# Altium schematic sheet 12_CONFIGURATIN_AND_ADC.SchDoc(12_CONFIGURATIN_AND_ADC)
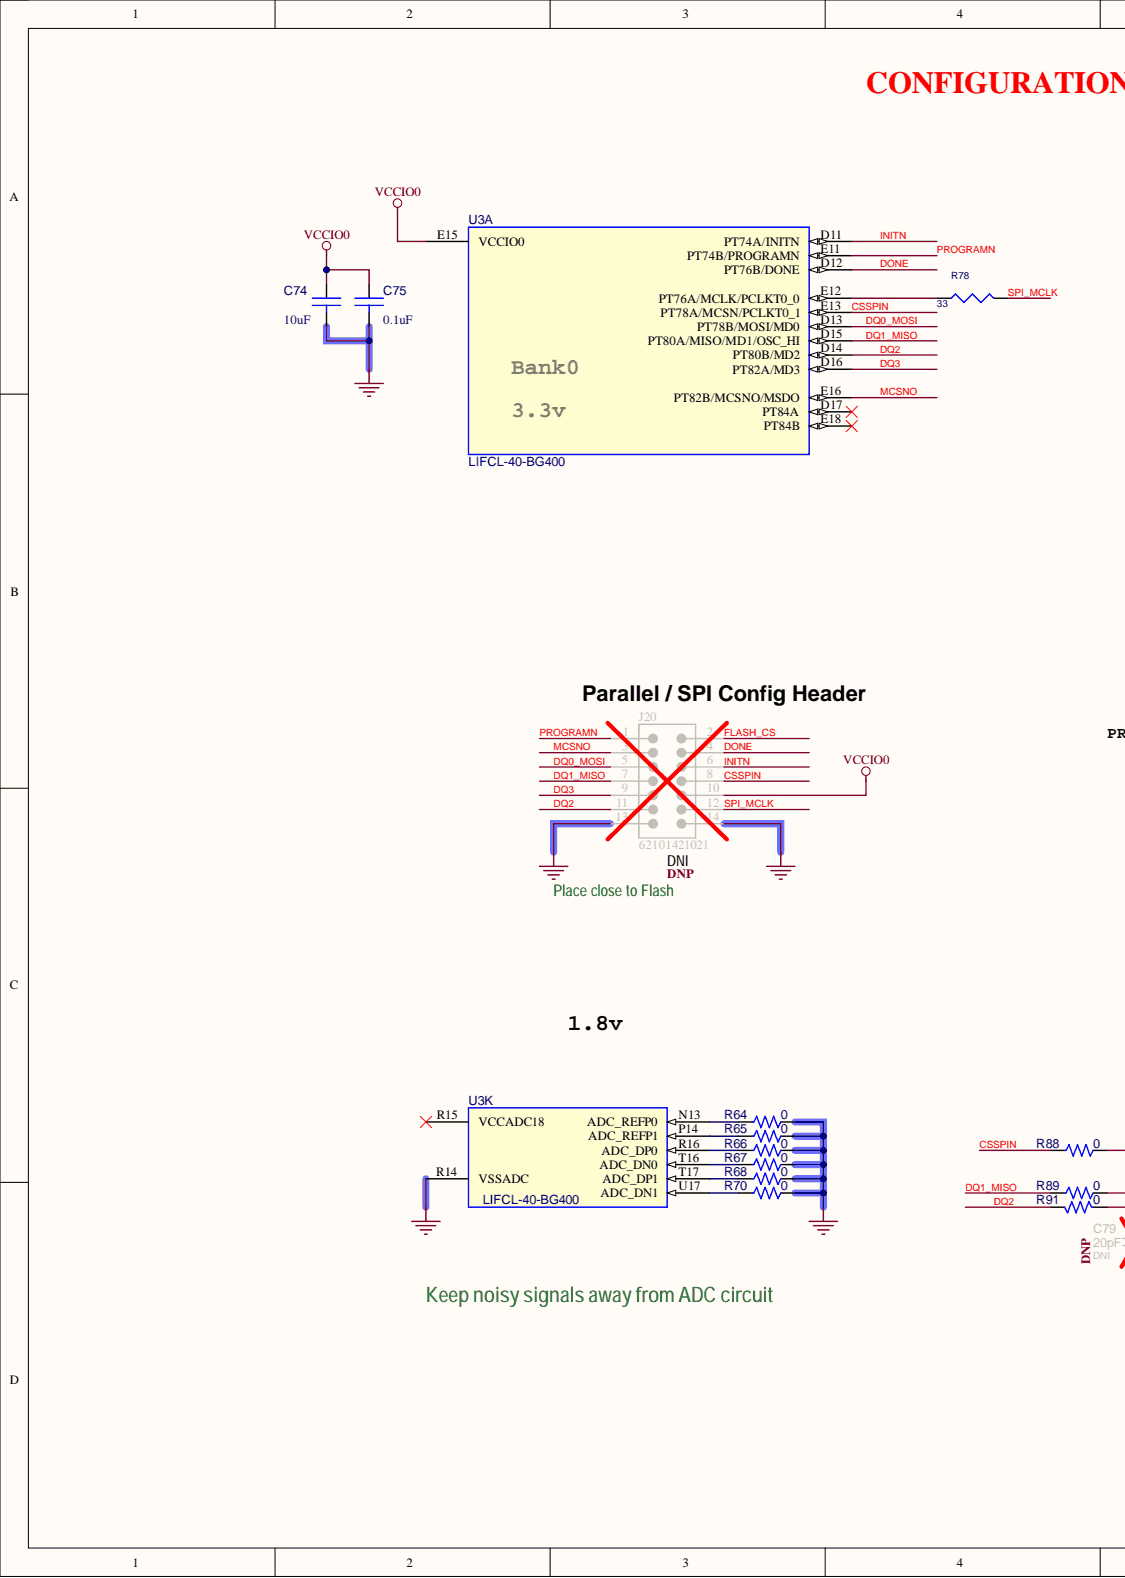
[diagram p0: 12_CONFIGURATIN_AND_ADC.SchDoc, left]
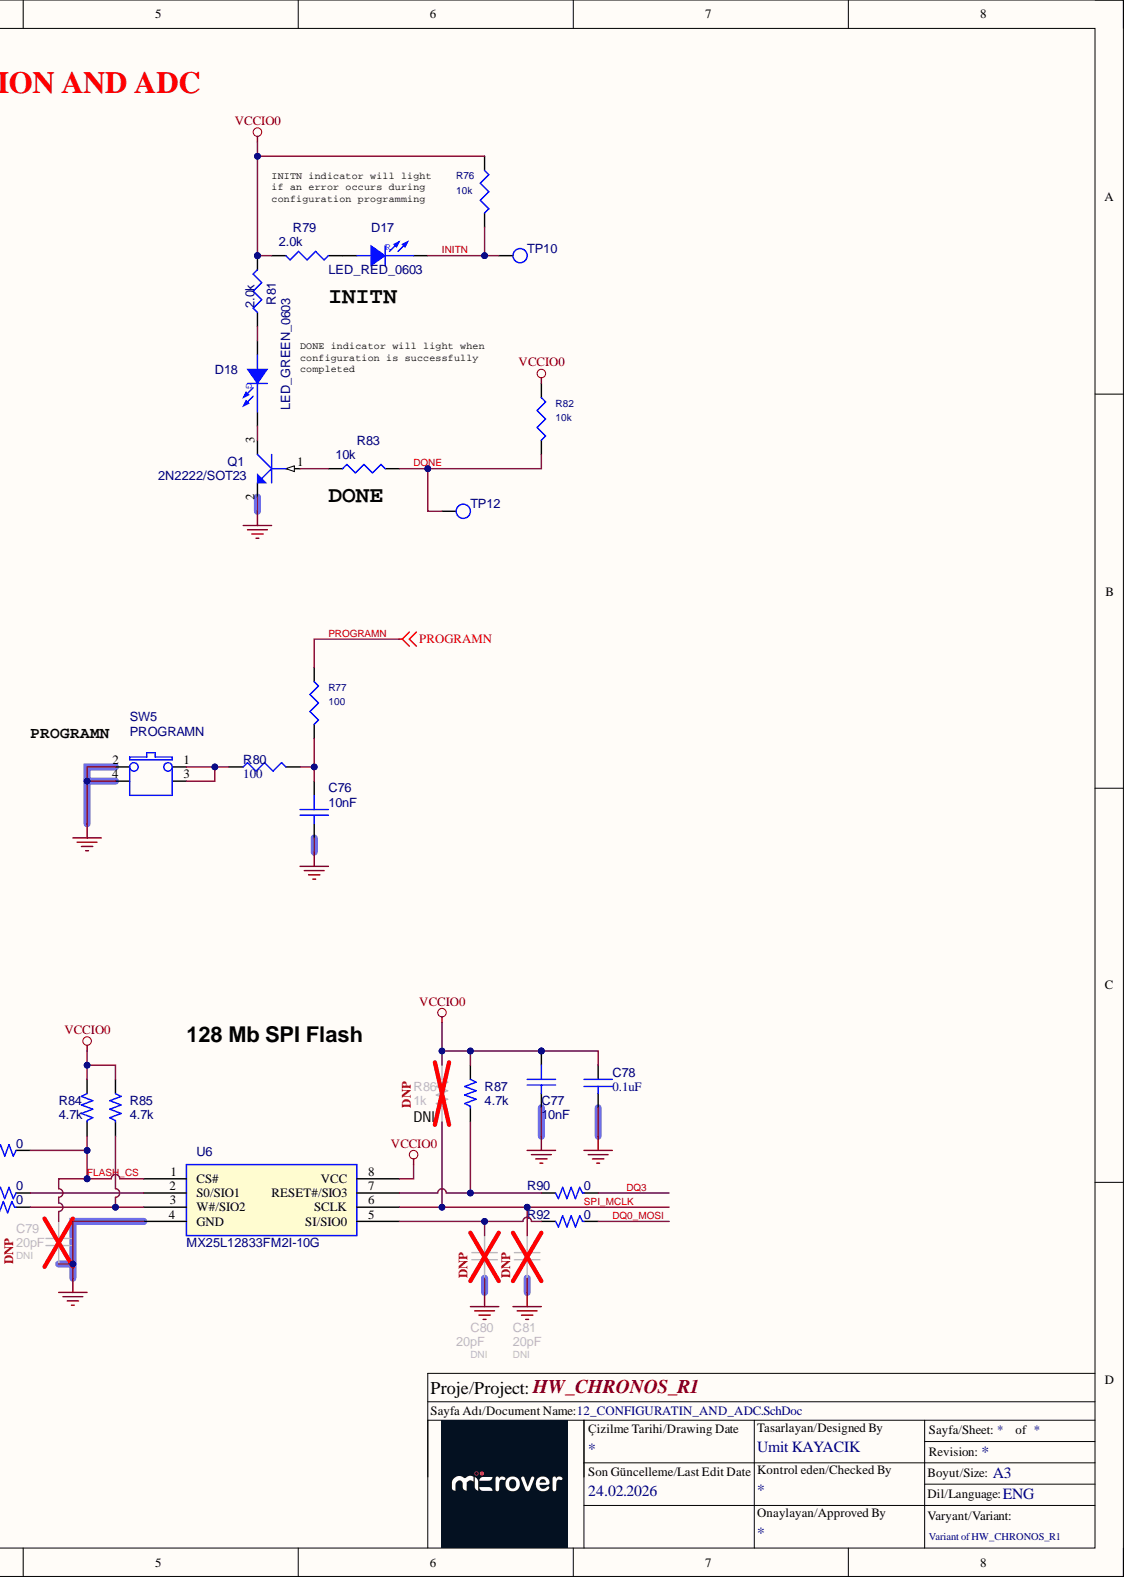
[diagram p1: 12_CONFIGURATIN_AND_ADC.SchDoc, right]

## Parts
C74  10uF  Part Reference: C74  [C0603]
C75  0.1uF  Part Reference: C75  [C0201]
C76  10nF  Part Reference: C76  [RLP-130-A]
C77  10nF  Part Reference: C77  [RLP-130-A]
C78  0.1uF  Part Reference: C78  [C0402]
C79  20pF  Assy: DNI  Part Reference: C79  populate: DNI  [C0402]
C80  20pF  [C0402]
C81  20pF  [c0402]
D17  LED_RED_0603  Part Reference: D17  [APT1608]
D18  LED_GREEN_0603  Part Reference: D18  [APT1608]
J20  62101421021  [62101421021]
Q1  2N2222/SOT23  Part Reference: Q1  [MMBT2222ALT-1]
R64  0  [R0201]
R65  0  [R0201]
R66  0  [R0201]
R67  0  [R0201]
R68  0  [R0201]
R70  0  [R0201]
R76  10k  Part Reference: R76  [R0402]
R77  100  Part Reference: R77  [R0402]
R78  33  Part Reference: R78  [R0402]
R79  2.0k  Part Reference: R79  [R0402]
R80  100  Part Reference: R80  [R0402]
R81  2.0k  Part Reference: R81  [R0402]
R82  10k  Part Reference: R82  [R0402]
R83  10k  Part Reference: R83  [R0402]
R84  4.7k  Part Reference: R84  [R0402]
R85  4.7k  Part Reference: R85  [R0402]
R86  1k  Assy: DNI  Part Reference: R86  [R0402]
R87  4.7k  Part Reference: R87  [R0402]
R88  0  Part Reference: R88  [R0402]
R89  0  Part Reference: R89  [R0402]
R90  0  Part Reference: R90  [R0402]
R91  0  Part Reference: R91  [R0402]
R92  0  Part Reference: R92  [R0402]
SW5  PROGRAMN  Part Reference: SW5  [4psmd_switch]
TP10  Part Reference: TP10  [TP_1MM]  (hidden comment: TestPoint)
TP12  Part Reference: TP12  [TP_1MM]  (hidden comment: TestPoint)
U3  LIFCL-40-BG400  [LIFCL-40-BG400]  (parts A,K)
U6  MX25L12833FM2I-10G  Part Reference: U6  [SO8_MX25L12833FM2I10G]

## Nets
GND: C74.2 C75.2 C76.2 C77.2 C78.2 C79.2 C80.2 C81.2 J20.13 J20.14 Q1.2 R64.2 R65.2 R66.2 R67.2 R68.2 R70.2 SW5.2 SW5.4 U3.R14(VSSADC) U6.4(GND)
VCCIO0: C74.1 C75.1 C77.1 C78.1 J20.10 R76.1 R79.2 R81.2 R82.1 R84.2 R85.2 R86.2 R87.2 U3.E15(VCCIO0) U6.8(VCC)
CSSPIN: J20.8 R88.1 U3.E13(PT78A/MCSN/PCLKT0_1)
DONE: J20.4 R82.2 R83.2 TP12.1 U3.D12(PT76B/DONE)
DQ0_MOSI: J20.5 R92.1 U3.D13(PT78B/MOSI/MD0)
DQ1_MISO: J20.7 R89.1 U3.D15(PT80A/MISO/MD1/OSC_HI)
DQ2: J20.11 R91.2 U3.D14(PT80B/MD2)
DQ3: J20.9 R90.1 U3.D16(PT82A/MD3)
FLASH_CS: C79.1 J20.2 R84.1 R88.2 U6.1(CS#)
INITN: D17.1 J20.6 R76.2 TP10.1 U3.D11(PT74A/INITN)
MCSNO: J20.3 U3.E16(PT82B/MCSNO/MSDO)
PROGRAMN: J20.1 R77.1 U3.E11(PT74B/PROGRAMN)
SPI_MCLK: C81.1 J20.12 R78.1 R86.1 U6.6(SCLK)
NetC76_1: C76.1 R77.2 R80.2
NetC80_1: C80.1 R92.2 U6.5(SI/SIO0)
NetD17_2: D17.2 R79.1
NetD18_1: D18.1 Q1.3
NetD18_2: D18.2 R81.1
NetQ1_1: Q1.1 R83.1
NetR64_1: R64.1 U3.N13(ADC_REFP0)
NetR65_1: R65.1 U3.P14(ADC_REFP1)
NetR66_1: R66.1 U3.R16(ADC_DP0)
NetR67_1: R67.1 U3.T16(ADC_DN0)
NetR68_1: R68.1 U3.T17(ADC_DP1)
NetR70_1: R70.1 U3.U17(ADC_DN1)
NetR78_2: R78.2 U3.E12(PT76A/MCLK/PCLKT0_0)
NetR80_1: R80.1 SW5.1 SW5.3
NetR85_1: R85.1 R91.1 U6.3(W#/SIO2)
NetR87_1: R87.1 R90.2 U6.7(RESET#/SIO3)
NetR89_2: R89.2 U6.2(S0/SIO1)

Not connected: U3 D17(PT84A) E18(PT84B) R15(VCCADC18)

## Library data of the parts
C74 = 10uF_0603: CAP CECAP CER 10UF 10V X5R 20% 0603; Manufacturer: Taiyo Yuden; Manufacturer Part Number: LMK107BJ106MALTD; Class: Discrete; Assy: -; Component Kind: Standard; Digikey P/N: 587-2562-1-ND; PCB Footprint: C0603; Value: 10uF
C75 = 0u1_0402: CAP CER 0.1UF 25V 10% X5R 0201; Manufacturer: Murata; Manufacturer Part Number: GRM033R61E104KE14J; Class: Discrete; Assy: -; Component Kind: Standard; Digikey P/N: 399-3521-2-ND; PCB Footprint: C0201; Value: 0.1uF
C76 C77 = CAP_1: Assy: -; Component Kind: Standard; PCB Footprint: RLP-130-A; Value: 10nF
C78 = 0u1_0402: CAP CER 0.1UF 25V 10% X5R 0402; Manufacturer: Murata; Manufacturer Part Number: GRM033R61E104KE14J; Class: Discrete; PCB Footprint: C0201; Assy: -; Component Kind: Standard; Digikey P/N: 399-3521-2-ND; Value: 0.1uF
C79 = CAP NP_Dup1: COMPFIXED: NO; COMPGROUP: 0; COMPHEIGHT: 0.000; COMPLOC: [1820.000,2015.000]; COMPROT: 270; COMPSIDE: TOP; FPLIST: SM/C_0402; PCB Footprint: RLP-132; COMPKEY: NO; COMPLOCKED: NO; Component Kind: Standard; DECOUPLER: NO; MEMORY: NO; Value: 20pF
C80 = CAP NP_Dup1: Part Reference: C80; Assy: DNI; COMPFIXED: NO; COMPGROUP: 0; COMPHEIGHT: 0.000; COMPLOC: [1820.000,2015.000]; COMPROT: 270; COMPSIDE: TOP; FPLIST: SM/C_0402; PCB Footprint: RLP-132; populate: DNI; COMPKEY: NO; COMPLOCKED: NO; Component Kind: Standard; DECOUPLER: NO; MEMORY: NO; Value: 20pF
C81 = CAP NP_Dup1: Part Reference: C81; Assy: DNI; COMPFIXED: NO; COMPGROUP: 0; COMPHEIGHT: 0.000; COMPLOC: [1820.000,2015.000]; COMPROT: 270; COMPSIDE: TOP; FPLIST: SM/C_0402; PCB Footprint: RLP-132; populate: DNI; COMPKEY: NO; COMPLOCKED: NO; Component Kind: Standard; DECOUPLER: NO; MEMORY: NO; Value: 20pF
D17 = LED-SMT1206_RED_0: Assy: -; Component Kind: Standard; PCB Footprint: APT1608; Value: LED_RED_0603
D18 = LED-SMT1206_GREEN_1: Assy: -; Component Kind: Standard; PCB Footprint: APT1608; Value: LED_GREEN_0603
J20 = CMP-1502-00928-4: Conn, Header, 14Pos, 2Row, 1.27Mm Rohs Compliant: Yes; Contact Resistance: 20mΩ; Current Rating: 1A; Gender: Male; Operating Supply Voltage: 100V; Component Kind: Standard; Lead Pitch: 1.27mm; Library Name: Altium Content Vault; Number of Pins: 14; Orientation: Vertical; RoHS: Non-Compliant
Q1 = 2N2222: Assy: -; Component Kind: Standard; PCB Footprint: MMBT2222ALT-1; Value: 2N2222/SOT23
R64-R68 R70 = R_Dup2: RES SMD 0.0OHM JUMPER 1/10W 0402; Manufacturer: Panasonic; Manufacturer Part Number: ERJ-2GE0R00X; Part Reference: R88; COMPFIXED: NO; COMPHEIGHT: 0.000; COMPLOC: [3325.000,1400.000]; COMPROT: 180; COMPSIDE: TOP; DIST: FLAT; FPLIST: AX/RC05; MAX_TEMP: RTMAX; PCB Footprint: R0402; POWER: RMAX; PSpiceTemplate: R^@REFDES %1 %2 ?TOLERANCE|R^@REFDES| @VALUE  ?TOLERANCE|\n.model R^@REFDES RES R=1 DEV=@TOLERANCE%|; SLOPE: RSMAX; VOLTAGE: RVMAX; Assy: -; COMPGROUP: 0; COMPKEY: NO; COMPLOCKED: NO; Component Kind: Standard; DECOUPLER: NO; MEMORY: NO; Simulation: R; Value: 0
R76 R82 = R_Dup3: RES SMD 10K OHM 1% 1/16W 0402; Manufacturer: Yageo; Manufacturer Part Number: RC0402FR-0710KL; Assy: -; Component Kind: Standard; MFG: Yageo; MFG P/N: RC0402FR-0710KL; PCB Footprint: R0402; Value: 10k
R77 = R_Dup3: RES SMD 100 OHM 1% 1/16W 0402; Manufacturer: Yageo; Manufacturer Part Number: RC0402FR-07100RL; Assy: -; Component Kind: Standard; MFG: Yageo; MFG P/N: RC0402FR-07100RL; PCB Footprint: R0402; Value: 100
R78 = R_Dup3: RES SMD 33 OHM 1% 1/10W 0402; Manufacturer: Panasonic; Manufacturer Part Number: ERJ-2RKF33R0X; Assy: -; Component Kind: Standard; PCB Footprint: R0402; Value: 33
R79 R81 = RES_0: RES SMD 1K OHM 1% 1/10W 0402; Manufacturer: Panasonic; Manufacturer Part Number: ERJ-2RKF1001X; Assy: -; Component Kind: Standard; PCB Footprint: R0402; Value: 2.0k
R80 = 0R_0603: RES SMD 100 OHM 1% 1/16W 0402; Manufacturer: Yageo; Manufacturer Part Number: RC0402FR-07100RL; Class: Discrete; Assy: -; Component Kind: Standard; Digikey P/N: 311-0.0GRTR-ND; MFG: Yageo; MFG P/N: RC0402FR-07100RL; PCB Footprint: R0402; Value: 100
R83 = RES_0: RES SMD 10K OHM 1% 1/16W 0402; Manufacturer: Yageo; Manufacturer Part Number: RC0402FR-0710KL; Assy: -; Component Kind: Standard; MFG: Yageo; MFG P/N: RC0402FR-0710KL; PCB Footprint: R0402; Value: 10k
R84 R85 R87 = R_Dup2: RES SMD 4.7K OHM 5% 1/10W 0402; Manufacturer: Panasonic; Manufacturer Part Number: ERJ-2GEJ472X; COMPFIXED: NO; COMPGROUP: 0; COMPHEIGHT: 0.000; COMPLOC: [970.000,1160.000]; COMPROT: 180; COMPSIDE: TOP; DIST: FLAT; FPLIST: AX/RC05,SM/R_0603; MAX_TEMP: RTMAX; POWER: RMAX; PSpiceTemplate: R^@REFDES %1 %2 ?TOLERANCE|R^@REFDES| @VALUE  ?TOLERANCE|\n.model R^@REFDES RES R=1 DEV=@TOLERANCE%|; SLOPE: RSMAX; VOLTAGE: RVMAX; Assy: -; COMPKEY: NO; COMPLOCKED: NO; Component Kind: Standard; DECOUPLER: NO; MEMORY: NO; MFG: Panasonic; MFG P/N: ERJ-2GEJ472X; PCB Footprint: R0402; Simulation: R; Value: 4.7k
R86 = R_Dup2: RES SMD 1K OHM 1% 1/10W 0402; Manufacturer: Panasonic; Manufacturer Part Number: ERJ-2RKF1001X; COMPFIXED: NO; COMPGROUP: 0; COMPHEIGHT: 0.000; COMPLOC: [970.000,1160.000]; COMPROT: 180; COMPSIDE: TOP; DIST: FLAT; FPLIST: AX/RC05,SM/R_0603; MAX_TEMP: RTMAX; POWER: RMAX; PSpiceTemplate: R^@REFDES %1 %2 ?TOLERANCE|R^@REFDES| @VALUE  ?TOLERANCE|\n.model R^@REFDES RES R=1 DEV=@TOLERANCE%|; SLOPE: RSMAX; VOLTAGE: RVMAX; COMPKEY: NO; COMPLOCKED: NO; Component Kind: Standard; DECOUPLER: NO; MEMORY: NO; PCB Footprint: R0402; Simulation: R; Value: 1k
R88-R92 = R_Dup2: RES SMD 0.0OHM JUMPER 1/10W 0402; Manufacturer: Panasonic; Manufacturer Part Number: ERJ-2GE0R00X; COMPFIXED: NO; COMPHEIGHT: 0.000; COMPLOC: [3325.000,1400.000]; COMPROT: 180; COMPSIDE: TOP; DIST: FLAT; FPLIST: AX/RC05; MAX_TEMP: RTMAX; POWER: RMAX; PSpiceTemplate: R^@REFDES %1 %2 ?TOLERANCE|R^@REFDES| @VALUE  ?TOLERANCE|\n.model R^@REFDES RES R=1 DEV=@TOLERANCE%|; SLOPE: RSMAX; VOLTAGE: RVMAX; Assy: -; COMPGROUP: 0; COMPKEY: NO; COMPLOCKED: NO; Component Kind: Standard; DECOUPLER: NO; MEMORY: NO; PCB Footprint: R0402; Simulation: R; Value: 0
SW5 = SW PUSHBUTTON-SPST/SM: SWITCH TACTILE SPST-NO 0.05A 12V; Man. Part #: 434153017835; Manufacturer: Wurth; Assy: -; Component Kind: Standard; Manufacturer Part Number: 434153017835; MFG: Wurth; MFG P/N: 434153017835; PCB Footprint: 4psmd_switch; Value: PROGRAMN
TP10 TP12 = T POINT R: PCB Footprint: TP50; Assy: -; Component Kind: Standard; Value: TestPoint
U3 = LIFCL-40-BG400: Part Reference: U3; SPLIT_INST: TRUE; SWAP_INFO: (S1+S2+S3+S4+S5+S6+S7+S8+S9+S10+S11+S12+S13+S14+S15); Assy: -; Component Kind: Standard; PCB Footprint: LIFCL-40-BG400; Value: LIFCL-40-BG400
U6 = N25QxxxA13xF8_0: IC FLASH 128MBIT 104MHZ 8SOP; Manufacturer: Macronix; Populate: DI; Assy: -; Component Kind: Standard; Manufacturer Part Number: MX25L12833FM2I-10G; PCB Footprint: SO8_MX25L12833FM2I10G; Value: MX25L12833FM2I-10G
